# Altium schematic sheet USB_CP2104_TF.SchDoc(USB_CP2104_TF)
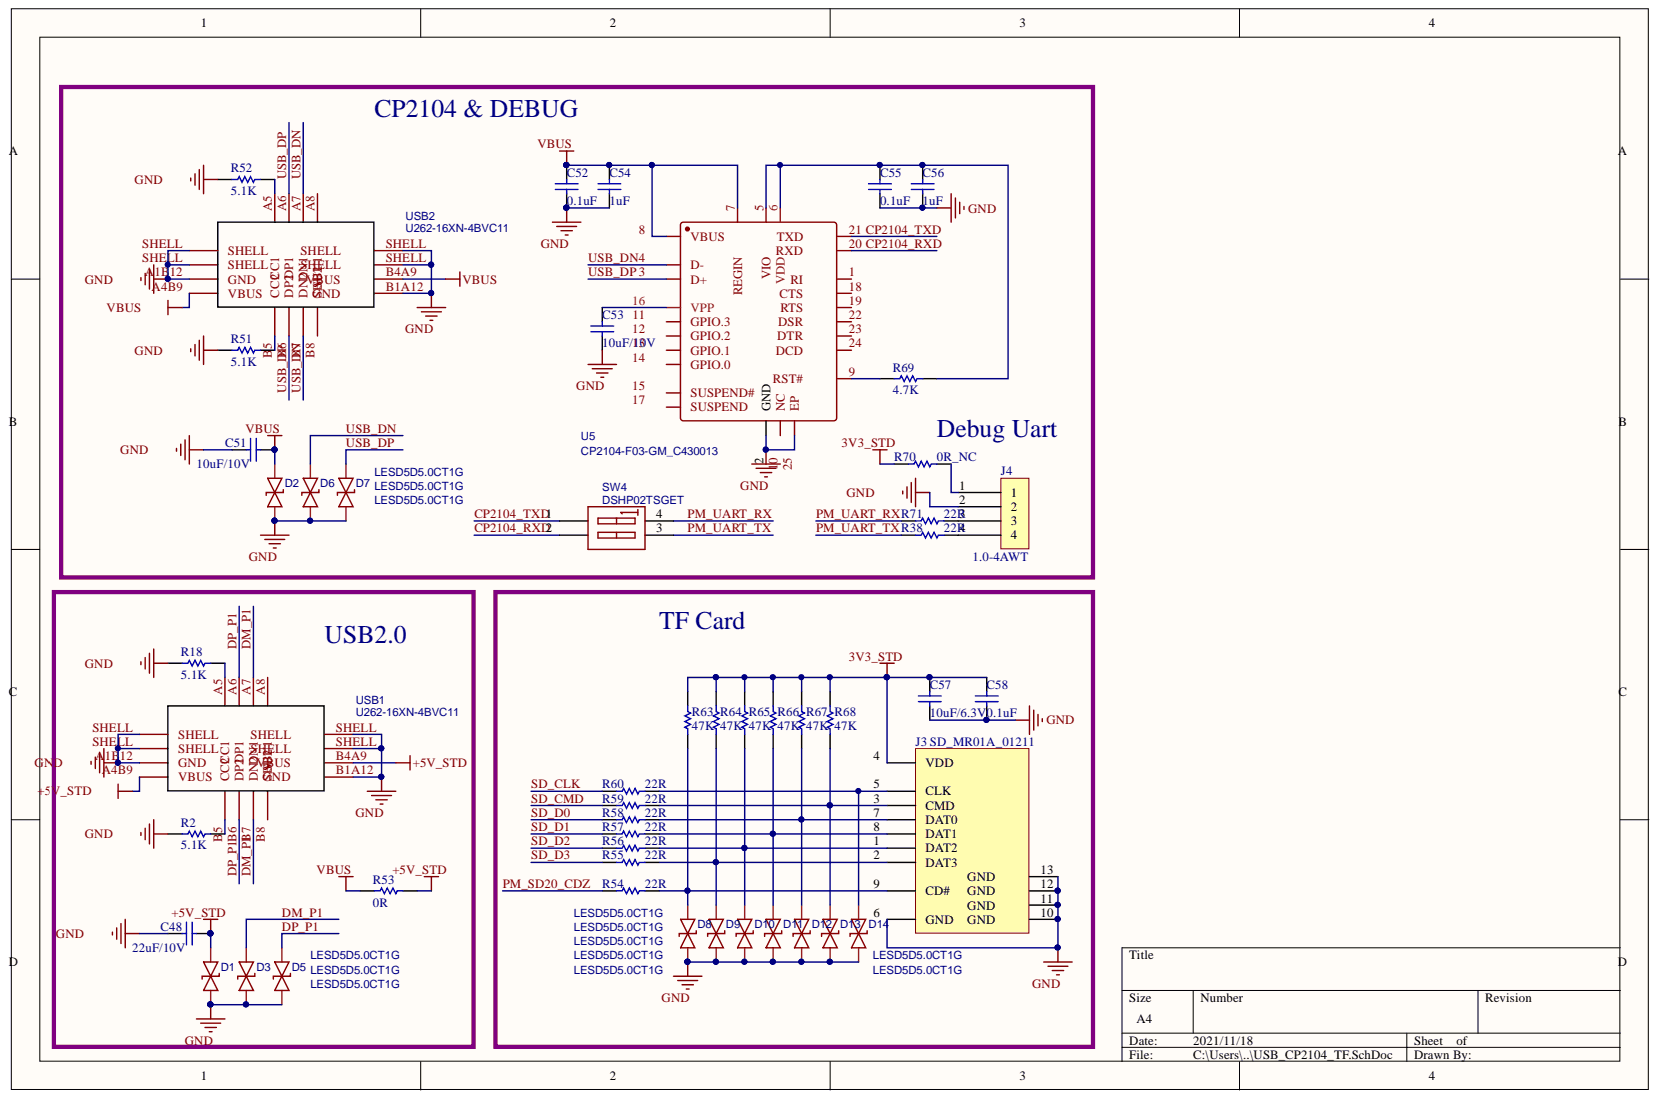
[diagram p0: USB_CP2104_TF.SchDoc]

## Parts
C48  22uF/10V  [0603]
C51  10uF/10V  [0603]
C52  0.1uF  [0402]
C53  10uF/10V  [0603]
C54  1uF  [0402]
C55  0.1uF  [0402]
C56  1uF  [0402]
C57  10uF/6.3V  [0402]
C58  0.1uF  [0402]
D1  LESD5D5.0CT1G  [SOD-523_L1.2-W0.8-LS1.6-BI]
D2  LESD5D5.0CT1G  [SOD-523_L1.2-W0.8-LS1.6-BI]
D3  LESD5D5.0CT1G  [SOD-523_L1.2-W0.8-LS1.6-BI]
D5  LESD5D5.0CT1G  [SOD-523_L1.2-W0.8-LS1.6-BI]
D6  LESD5D5.0CT1G  [SOD-523_L1.2-W0.8-LS1.6-BI]
D7  LESD5D5.0CT1G  [SOD-523_L1.2-W0.8-LS1.6-BI]
D8  LESD5D5.0CT1G  [SOD-523_L1.2-W0.8-LS1.6-BI]
D9  LESD5D5.0CT1G  [SOD-523_L1.2-W0.8-LS1.6-BI]
D10  LESD5D5.0CT1G  [SOD-523_L1.2-W0.8-LS1.6-BI]
D11  LESD5D5.0CT1G  [SOD-523_L1.2-W0.8-LS1.6-BI]
D12  LESD5D5.0CT1G  [SOD-523_L1.2-W0.8-LS1.6-BI]
D13  LESD5D5.0CT1G  [SOD-523_L1.2-W0.8-LS1.6-BI]
D14  LESD5D5.0CT1G  [SOD-523_L1.2-W0.8-LS1.6-BI]
J3  SD_MR01A_01211  [SD-MR01A-01211]
J4  1.0-4AWT  [CONN-SMD_1.0-4AWT]
R2  5.1K  [0402]
R18  5.1K  [0402]
R38  22R  [0402]
R51  5.1K  [0402]
R52  5.1K  [0402]
R53  0R  [0603]
R54  22R  [0402]
R55  22R  [0402]
R56  22R  [0402]
R57  22R  [0402]
R58  22R  [0402]
R59  22R  [0402]
R60  22R  [0402]
R63  47K  [0402]
R64  47K  [0402]
R65  47K  [0402]
R66  47K  [0402]
R67  47K  [0402]
R68  47K  [0402]
R69  4.7K  [0402]
R70  0R_NC  [0402]
R71  22R  [0402]
SW4  DSHP02TSGET  [SW-SMD_4P_DSHP02TSGET]
U5  CP2104-F03-GM_C430013  [QFN-24_L4.0-W4.0-P0.50-TL-EP2.7]
USB1  U262-16XN-4BVC11  [TYPEC-12PIN - duplicate]
USB2  U262-16XN-4BVC11  [TYPEC-12PIN - duplicate]

## Nets
3V3_STD: C57.1 C58.1 J3.4(VDD) R63.1 R64.1 R65.1 R66.1 R67.1 R68.1 R70.2
+5V_STD: C48.2 D1.2 R53.1 USB1.A4B9(VBUS) USB1.B4A9
GND: C48.1 C51.1 C52.2 C53.1 C54.2 C55.2 C56.2 C57.2 C58.2 D1.1 D2.1 D3.1 D5.1 D6.1 D7.1 D8.1 D9.1 D10.1 D11.1 D12.1 D13.1 D14.1 J3.6(GND) J3.10 J3.11 J3.12 J3.13 J4.2 R2.2 R18.2 R51.2 R52.2 U5.2(GND) U5.25(EP) USB1.A1B12(GND) USB1.B1A12 USB1.SHELL USB2.A1B12(GND) USB2.B1A12 USB2.SHELL
VBUS: C51.2 C52.1 C54.1 D2.2 R53.2 U5.7 U5.8(VBUS) USB2.A4B9(VBUS) USB2.B4A9
CP2104_RXD: SW4.2 U5.20
CP2104_TXD: SW4.1 U5.21
DM_P1: D3.2 USB1.A7 USB1.B7(DN2)
DP_P1: D5.2 USB1.A6 USB1.B6(DP2)
PM_SD20_CDZ: R54.2
PM_UART_RX: R71.2 SW4.4
PM_UART_TX: R38.2 SW4.3
SD_CLK: R60.2
SD_CMD: R59.2
SD_D0: R58.2
SD_D1: R57.2
SD_D2: R56.2
SD_D3: R55.2
USB_DN: D6.2 U5.4(D-) USB2.A7 USB2.B7(DN2)
USB_DP: D7.2 U5.3(D+) USB2.A6 USB2.B6(DP2)
NetC53_2: C53.2 U5.16(VPP)
NetC55_1: C55.1 C56.1 R69.2 U5.5 U5.6
NetD8_2: D8.2 J3.9(CD#) R54.1 R63.2
NetD9_2: D9.2 J3.2(DAT3) R55.1 R64.2
NetD10_2: D10.2 J3.1(DAT2) R56.1 R65.2
NetD11_2: D11.2 J3.8(DAT1) R57.1 R66.2
NetD12_2: D12.2 J3.7(DAT0) R58.1 R67.2
NetD13_2: D13.2 J3.3(CMD) R59.1 R68.2
NetD14_2: D14.2 J3.5(CLK) R60.1
NetJ4_1: J4.1 R70.1
NetJ4_3: J4.3 R71.1
NetJ4_4: J4.4 R38.1
NetR2_1: R2.1 USB1.B5(CC2)
NetR18_1: R18.1 USB1.A5
NetR51_1: R51.1 USB2.B5(CC2)
NetR52_1: R52.1 USB2.A5
NetR69_1: R69.1 U5.9

Not connected: U5 1 10(NC) 11(GPIO.3) 12(GPIO.2) 13(GPIO.1) 14(GPIO.0) 15(SUSPEND#) 17(SUSPEND) 18 19 22 23 24; USB1 A8 B8(SBU2); USB2 A8 B8(SBU2)

## Library data of the parts
C48 C51-C58 = Cap: Capacitor; PartNumber: CAP100NF; Component Kind: Standard; LatestRevisionDate: 17-Jul-2002; LatestRevisionNote: Re-released for DXP Platform.; Library Name: Miscellaneous Devices.IntLib; PackageReference: 0402; Published: 8-Jun-2000; Signal Integrity: Cap; Simulation: CAP; Publisher: Altium Limited
  C48: Value: 22uF/10V
  C51 C53: Value: 10uF/10V
  C52 C55 C58: Value: 0.1uF
  C54 C56: Value: 1uF
  C57: Value: 10uF/6.3V
D1-D3 D5-D7 = LESD5D5.0CT1G: BOM_Manufacturer: Leshan Radio; Contributor: LCSC; BOM_JLCPCB Part Class: Extended Part; BOM_Manufacturer Part: LESD5D5.0CT1G; BOM_Supplier: LCSC; BOM_Supplier Part: C383211; Component Kind: Standard; spicePre: D; spiceSymbolName: LESD5D5.0CT1G
D8-D14 = LESD5D5.0CT1G: BOM_Manufacturer: Leshan Radio; BOM_Paste Type: expand; Contributor: LCSC; BOM_Manufacturer Part: LESD5D5.0CT1G; BOM_Supplier: LCSC; BOM_Supplier Part: C383211; Component Kind: Standard; Library Name: HM2.SchLib; spicePre: D; spiceSymbolName: LESD5D5.0CT1G
J4 = SIP4: Component Kind: Standard; Library Name: HM2.SchLib; VALUE: 1.0-4AWT
R2 R18 R38 R51-R60 R63-R71 = Res1: Resistor; PartNumber: RES2.2K; Component Kind: Standard; LatestRevisionDate: 17-Jul-2002; LatestRevisionNote: Re-released for DXP Platform.; Library Name: Miscellaneous Devices.IntLib; PackageReference: 0402; Published: 8-Jun-2000; Signal Integrity: Res; Simulation: RESISTOR; Publisher: Altium Limited
  R2 R18 R51 R52: Value: 5.1K
  R38 R54-R60 R71: Value: 22R
  R53: Value: 0R
  R63-R68: Value: 47K
  R69: Value: 4.7K
  R70: Value: 0R_NC
SW4 = DSHP02TSGET: BOM_Manufacturer: KE; BOM_JLCPCB Part Class: Extended Part; BOM_Manufacturer Part: DSHP02TSGET; BOM_Supplier: LCSC; BOM_Supplier Part: C40735; Component Kind: Standard; spicePre: S; spiceSymbolName: DSHP02TSGET
U5 = CP2104-F03-GM_C430013: BOM_Manufacturer: SILICON LABS; Contributor: LCSC; BOM_JLCPCB Part Class: Extended Part; BOM_Manufacturer Part: CP2104-F03-GM; BOM_Supplier: LCSC; BOM_Supplier Part: C430013; Component Kind: Standard; Library Name: HM2.SchLib; spicePre: U; spiceSymbolName: CP2104-F03-GM_C430013
USB1 USB2 = U262-16XN-4BVC11: BOM_Manufacturer: XKB Enterprise; Contributor: LCSC; BOM_Manufacturer Part: U262-16XN-4BVC11; BOM_Supplier: LCSC; BOM_Supplier Part: C319148; Component Kind: Standard; Library Name: HM2.SchLib; spicePre: U; spiceSymbolName: U262-16XN-4BVC11
J3 = SD_MR01A_01211: Component Kind: Standard; Library Name: HM2.SchLib
